# Altium schematic sheet [14] MISC.SchDoc("[14] MISC")
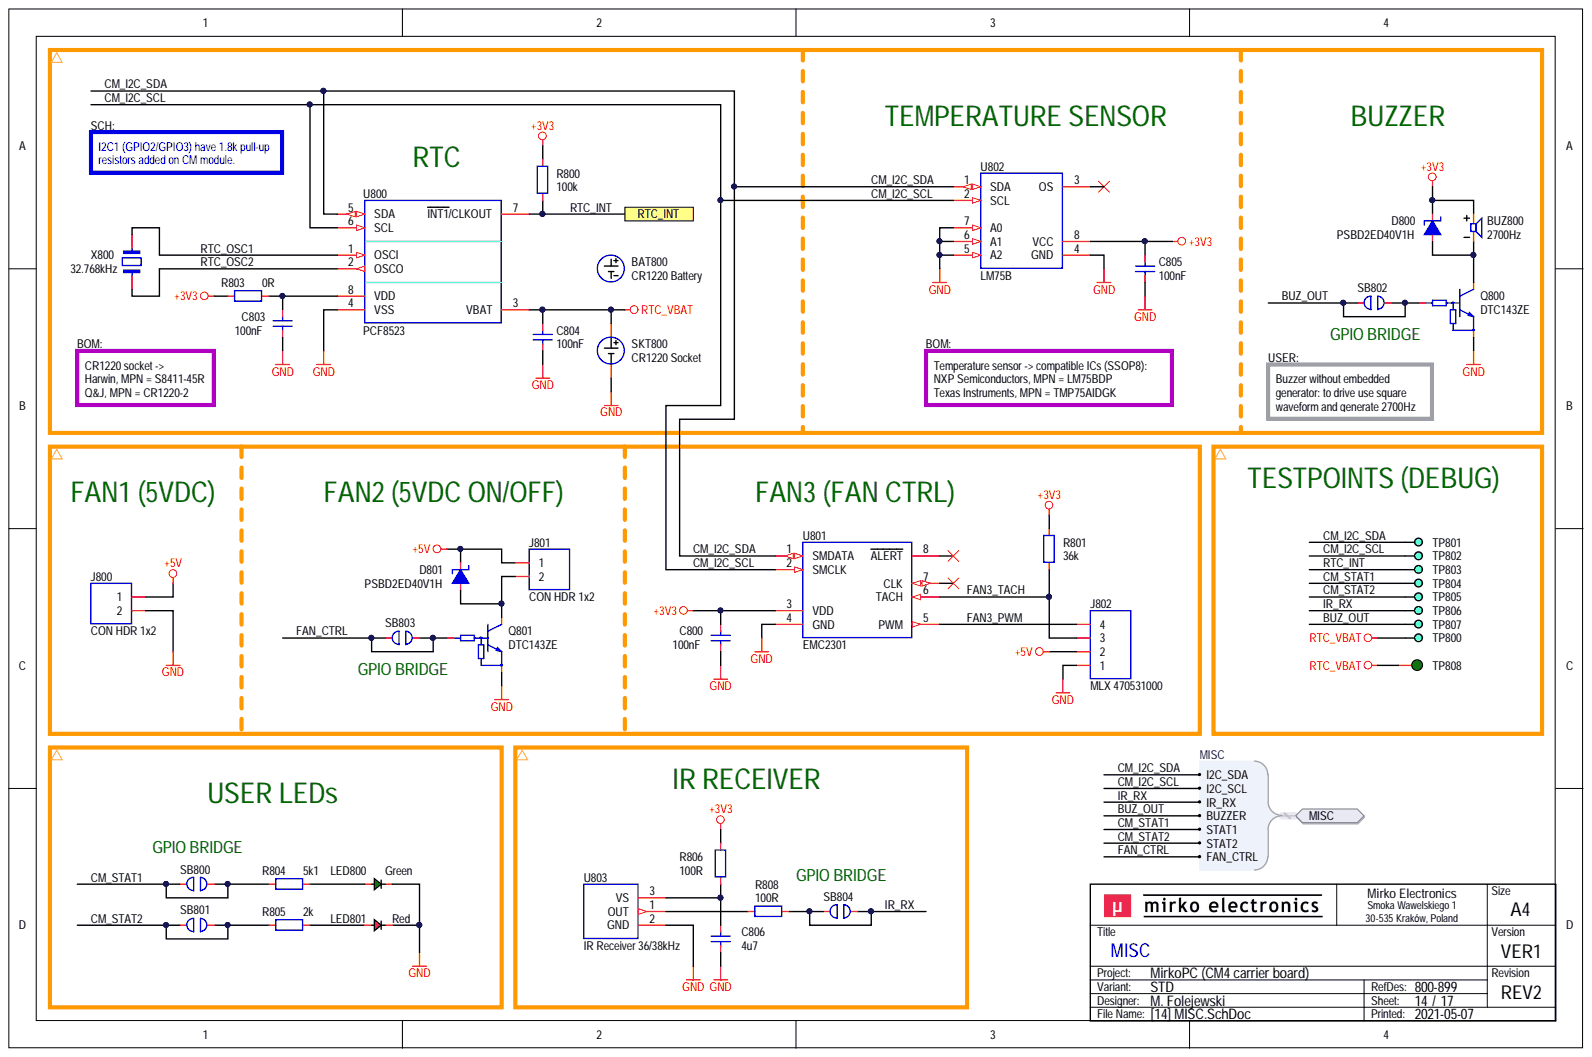
[diagram p0: [14] MISC.SchDoc]

## Parts
BAT800  CR1220 Battery  (mechanical)
BUZ800  2700Hz  [BUZ_750X750H250_R]
C800  100nF  [CAP_0603_R]
C803  100nF  [CAP_0603_R]
C804  100nF  [CAP_0603_R]
C805  100nF  [CAP_0603_R]
C806  4u7  [CAP_0603_R]
D800  PSBD2ED40V1H  [DIO_0603_UNI_R]
D801  PSBD2ED40V1H  [DIO_0603_UNI_R]
J800  CON HDR 1x2  [CON_HDR_P254_1X02_STR]
J801  CON HDR 1x2  [CON_HDR_P254_1X02_STR]
J802  MLX 470531000  MPN: 470531000  [CON_MLX_470531000]
LED800  Green  [LED_0805_GRN_R]
LED801  Red  [LED_0805_RED_R]
Q800  DTC143ZE  [PKG_SOT523_SC75_SOT416_P100_R]
Q801  DTC143ZE  [PKG_SOT523_SC75_SOT416_P100_R]
R800  100k  [RES_0603_R]
R801  36k  [RES_0603_R]
R803  0R  [RES_0603_R]
R804  5k1  [RES_0603_R]
R805  2k  [RES_0603_R]
R806  100R  [RES_0603_R]
R808  100R  [RES_0603_R]
SB800  ?  (value not drawn)  (no BOM)
SB801  ?  (value not drawn)  (no BOM)
SB802  ?  (value not drawn)  (no BOM)
SB803  ?  (value not drawn)  (no BOM)
SB804  ?  (value not drawn)  (no BOM)
SKT800  CR1220 Socket  [SKT_HRW_S8411-45_BAT_R]
TP800  DEBUG  [PCB_TP_DBG_50]  (value not drawn)  (no BOM)
TP801  DEBUG  [PCB_TP_DBG_50]  (value not drawn)  (no BOM)
TP802  DEBUG  [PCB_TP_DBG_50]  (value not drawn)  (no BOM)
TP803  DEBUG  [PCB_TP_DBG_50]  (value not drawn)  (no BOM)
TP804  DEBUG  [PCB_TP_DBG_50]  (value not drawn)  (no BOM)
TP805  DEBUG  [PCB_TP_DBG_50]  (value not drawn)  (no BOM)
TP806  DEBUG  [PCB_TP_DBG_50]  (value not drawn)  (no BOM)
TP807  DEBUG  [PCB_TP_DBG_50]  (value not drawn)  (no BOM)
TP808  ICT  [PCB_TP_ICT_100]  (value not drawn)  (no BOM)
U800  PCF8523  [ICC_SO8_SOP8_490X390X160_P127_R]
U801  EMC2301  [ICC_TSSOP8_300X300X110P65]
U802  LM75B  [ICC_TSSOP8_300X300X110P65]
U803  IR Receiver 36/38kHz  Component Subtype: IR Receiver  [OPT_VSH_TSOP3XXXX]
X800  32.768kHz

## Ports
MISC, MISC.BUZZER, MISC.FAN_CTRL, MISC.I2C_SCL, MISC.I2C_SDA, MISC.IR_RX, MISC.STAT1, MISC.STAT2, RTC_INT

## Nets
+3V3: BUZ800.2 C800.2 C805.2 D800.1 R800.2 R801.2 R803.1 R806.2 U801.3(VDD) U802.8(VCC)
+5V: D801.1 J800.1 J801.1 J802.2
GND: C800.1 C803.1 C804.1 C805.1 C806.1 J800.2 J802.1 LED800.1 LED801.1 Q800.2 Q801.2 SKT800.1 U800.4(VSS) U801.4(GND) U802.4(GND) U802.5(A2) U802.6(A1) U802.7(A0) U803.2
RTC_VBAT: C804.2 SKT800.2 TP800.1 TP808.1 U800.3(VBAT)
BUZ_OUT: Q800.1 SB802.1 SB802.2 TP807.1 ; port MISC
CM_I2C_SCL: TP802.1 U800.6(SCL) U801.2(SMCLK) U802.2(SCL) ; port MISC
CM_I2C_SDA: TP801.1 U800.5(SDA) U801.1(SMDATA) U802.1(SDA) ; port MISC
CM_STAT1: R804.1 SB800.1 SB800.2 TP804.1 ; port MISC
CM_STAT2: R805.1 SB801.1 SB801.2 TP805.1 ; port MISC
FAN3_PWM: J802.4 U801.5(PWM)
FAN3_TACH: J802.3 R801.1 U801.6(TACH)
FAN_CTRL: Q801.1 SB803.1 SB803.2 ; port MISC
IR_RX: R808.1 SB804.1 SB804.2 TP806.1 ; port MISC
RTC_INT: R800.1 TP803.1 U800.7(INT1/CLKOUT) ; port RTC_INT
RTC_OSC1: U800.1(OSCI) X800.4
RTC_OSC2: U800.2(OSCO) X800.1
NetBUZ800_1: BUZ800.1 D800.2 Q800.3
NetC803_2: C803.2 R803.2 U800.8(VDD)
NetC806_2: C806.2 R806.1 U803.3
NetD801_2: D801.2 J801.2 Q801.3
NetLED800_2: LED800.2 R804.2
NetLED801_2: LED801.2 R805.2
NetR808_2: R808.2 U803.1

Not connected: U801 7(CLK) 8(ALERT); U802 3(OS)

## Library data of the parts
BAT800 = BAT_RND: Battery; Round Type;; Component Subtype: Coin Battery; Value: CR1220 Battery; Supplier: ?; Mounting Type: -; Component Type: Battery; Library Name: MirFol_lib.SchLib
BUZ800 = BUZ_POL: Buzzer; Manufacturer: Jiangsu Huaneng Elec; Package (Case): 7.5x7.5x2.5mm; Value: 2700Hz; Supplier: LCSC; MPN: MLT-7525; Mounting Type: SMD; Component Type: Buzzer; Component Subtype: Buzzer; Component Kind: Standard; Library Name: MirFol_lib.SchLib
C800 C803-C805 = CAP-0008: Capacitor; MLCC; 100nF; TBD; TBD; TBD; TBD; SMD; 0603 (1608);; Database Table Name: CAPACITOR$; Value: 100nF; Supplier: ?; Package (Case): 0603 (1608); MPN: TBD; Mounting Type: SMD; Manufacturer: TBD; Component Type: Capacitor; Component Subtype: MLCC; Component Kind: Standard; Library Name: MirFol_db_local.DbLib
C806 = CAP-0023: Capacitor; MLCC; GENERIC; TBD; TBD; TBD; TBD; SMD; 0603 (1608);; Database Table Name: CAPACITOR$; Value: 4u7; Supplier: ?; Package (Case): 0603 (1608); MPN: GENERIC; Mounting Type: SMD; Manufacturer: GENERIC; Component Type: Capacitor; Component Subtype: MLCC; Component Kind: Standard; Library Name: MirFol_db_local.DbLib
D800 D801 = DIO_SHT_P2_KA: Diode; Schottky;; Manufacturer: Prisemi Electronics; Package (Case): SMD 0603 (1608); Component Subtype: Schottky Diode; Value: PSBD2ED40V1H; Supplier: LCSC; MPN: PSBD2ED40V1H; Mounting Type: SMD; Component Type: Diode; Component Kind: Standard; Library Name: MirFol_lib.SchLib
J800 J801 = CON-0020: Connector; Pin Header; 1 x 2 pins; 2.54mm; Male; TBD; TBD; THT; THT;; MPN: GENERIC; Database Table Name: CONNECTOR$; Value: CON HDR 1x2; Supplier: ?; Package (Case): THT; Mounting Type: THT; Manufacturer: GENERIC; Component Type: Connector; Component Subtype: Pin Header; Component Kind: Standard; Library Name: MirFol_db_local.DbLib
J802 = CON_1X04_COL: Connector; 1 Row;; Manufacturer: Molex; Package (Case): THT; Component Subtype: Pin header; Value: MLX 470531000; Mounting Type: THT; Component Type: Connector; Component Kind: Standard; Library Name: MirFol_lib.SchLib
LED800 = DIO_LED_GRN: Diode; LED; Green;; MPN: NCD0805G1; Manufacturer: Foshan NationStar Optoelectronics; Package (Case): 0805 (2012); Component Subtype: LED Diode; Value: Green; Supplier: LCSC; Mounting Type: SMD; Component Type: LED; Component Kind: Standard; Library Name: MirFol_lib.SchLib
LED801 = DIO_LED_RED: Diode; LED; Red;; MPN: NCD0805R1; Manufacturer: Foshan NationStar Optoelectronics; Package (Case): 0805 (2012); Component Subtype: LED Diode; Value: Red; Supplier: LCSC; Mounting Type: SMD; Component Type: LED; Component Kind: Standard; Library Name: MirFol_lib.SchLib
Q800 Q801 = TRA_BJT_NPN_DIG_BEC: Transistor; BJT; NPN; Digital;; Component Subtype: NPN Transistor; Value: DTC143ZE; Supplier: ?; Mounting Type: SMD; Component Type: Transistor; Component Kind: Standard; Library Name: MirFol_lib.SchLib
R800 = RES-0029: Resistor; Thick Film; 100k; 1%; 100mW; 100PPM; 75V; Standard; SMD; 0603 (1608);; MPN: TBD; Manufacturer: Yageo Corporation; Database Table Name: RESISTOR$; Value: 100k; Supplier: ?; Package (Case): 0603 (1608); Mounting Type: SMD; Component Type: Resistor; Component Subtype: Thick Film; Component Kind: Standard; Library Name: MirFol_db_local.DbLib
R801 = RES-0054: Resistor; Thick Film; GENERIC; TBD; TBD; TBD; TBD; TBD; SMD; 0603 (1608);; Database Table Name: RESISTOR$; Value: 36k; Supplier: ?; Package (Case): 0603 (1608); MPN: GENERIC; Mounting Type: SMD; Manufacturer: GENERIC; Component Type: Resistor; Component Subtype: Thick Film; Component Kind: Standard; Library Name: MirFol_db_local.DbLib
R803 = RES-0052: Resistor; Thick Film; 0R; 1%; TBD; TBD; TBD; Standard; SMD; 0603 (1608);; Database Table Name: RESISTOR$; Value: 0R; Supplier: ?; Package (Case): 0603 (1608); MPN: TBD; Mounting Type: SMD; Manufacturer: TBD; Component Type: Resistor; Component Subtype: Thick Film; Component Kind: Standard; Library Name: MirFol_db_local.DbLib
R804 = RES-0043: Resistor; Thick Film; 5k1; 1%; 100mW; 100PPM; 75V; Standard; SMD; 0603 (1608);; MPN: TBD; Manufacturer: Yageo Corporation; Database Table Name: RESISTOR$; Value: 5k1; Supplier: ?; Package (Case): 0603 (1608); Mounting Type: SMD; Component Type: Resistor; Component Subtype: Thick Film; Component Kind: Standard; Library Name: MirFol_db_local.DbLib
R805 = RES-0033: Resistor; Thick Film; 2k2; 1%; 100mW; 100PPM; 75V; Standard; SMD; 0603 (1608);; MPN: TBD; Manufacturer: Yageo Corporation; Database Table Name: RESISTOR$; Value: 2k; Supplier: ?; Package (Case): 0603 (1608); Mounting Type: SMD; Component Type: Resistor; Component Subtype: Thick Film; Component Kind: Standard; Library Name: MirFol_db_local.DbLib
R806 R808 = RES-0026: Resistor; Thick Film; 100R; 1%; 100mW; 100PPM; 75V; Standard; SMD; 0603 (1608);; MPN: TBD; Manufacturer: Yageo Corporation; Database Table Name: RESISTOR$; Value: 100R; Supplier: ?; Package (Case): 0603 (1608); Mounting Type: SMD; Component Type: Resistor; Component Subtype: Thick Film; Component Kind: Standard; Library Name: MirFol_db_local.DbLib
SB800-SB804 = PCB_SB_2P: PCB; Solder bridge; SMD;; Library Name: MirFol_lib.SchLib
SKT800 = SKT_BAT_RND_P2: Socket; Battery;; Component Subtype: Battery Socket; Value: CR1220 Socket; Supplier: ?; Mounting Type: SMD; Component Type: Socket; Component Kind: Standard; Library Name: MirFol_lib.SchLib
TP800-TP807 = PCB_TP_DBG: PCB Marking; Test Point (Debug);; Value: DEBUG; Supplier: ?; Mounting Type: -; Component Type: Testpoint; Component Subtype: -; Library Name: MirFol_lib.SchLib
TP808 = PCB_TP_ICT: Test Point (ICT); Specification: Testpoint (for ICT), SMD pad; Value: ICT; MPN: -; Mounting Type: Non component; Manufacturer: -; Component Type: Testpoint (for ICT); Library Name: MirFol_lib.SchLib
U800 = ICC-0010: IC; RTC; Real-Time Clock TBD; SMD; SO8/SOP8;; MPN: PCF8523T/1; Manufacturer: NXP Semiconductors; Database Table Name: IC (MISC)$; Value: PCF8523; Supplier: ?; Package (Case): SO8/SOP8; Mounting Type: SMD; Component Type: IC; Component Subtype: RTC; Component Kind: Standard; Library Name: MirFol_db_local.DbLib
U801 = ICC_MCP_EMC2301_MSOP-8: IC; Fan controller;; MPN: EMC2301-1-ACZL-TR; Manufacturer: Microchip Technology; Package (Case): MSOP-8; Value: EMC2301; Mounting Type: SMD; Component Type: IC; Component Subtype: Fan controller; Component Kind: Standard; Library Name: MirFol_lib.SchLib
U802 = ICC_NXP_LM75B: ICC; Temperature Sensor;; MPN: LM75BDP; Manufacturer: NXP Semiconductors; Value: LM75B; Supplier: ?; Mounting Type: SMD; Component Type: IC; Component Subtype: Temperature Sensor; Component Kind: Standard; Library Name: MirFol_lib.SchLib
U803 = OPT_VSH_TSOP34XXX: Opto; IR Receiver; MPN: IRM-56384; Manufacturer: Everlight Electronics; Package (Case): THT; Value: IR Receiver 36/38kHz; Supplier: LCSC; Mounting Type: THT; Component Type: Sensor; Component Kind: Standard; Library Name: MirFol_lib.SchLib
X800 = OSC_XTL_P4_XNNX: Oscillator; Crystal;; Value: 32.768kHz; Supplier: ?; Mounting Type: SMD; Component Type: Crystal; Component Subtype: Crystal; Component Kind: Standard; Library Name: MirFol_lib.SchLib
